# Altium schematic sheet power.SchDoc
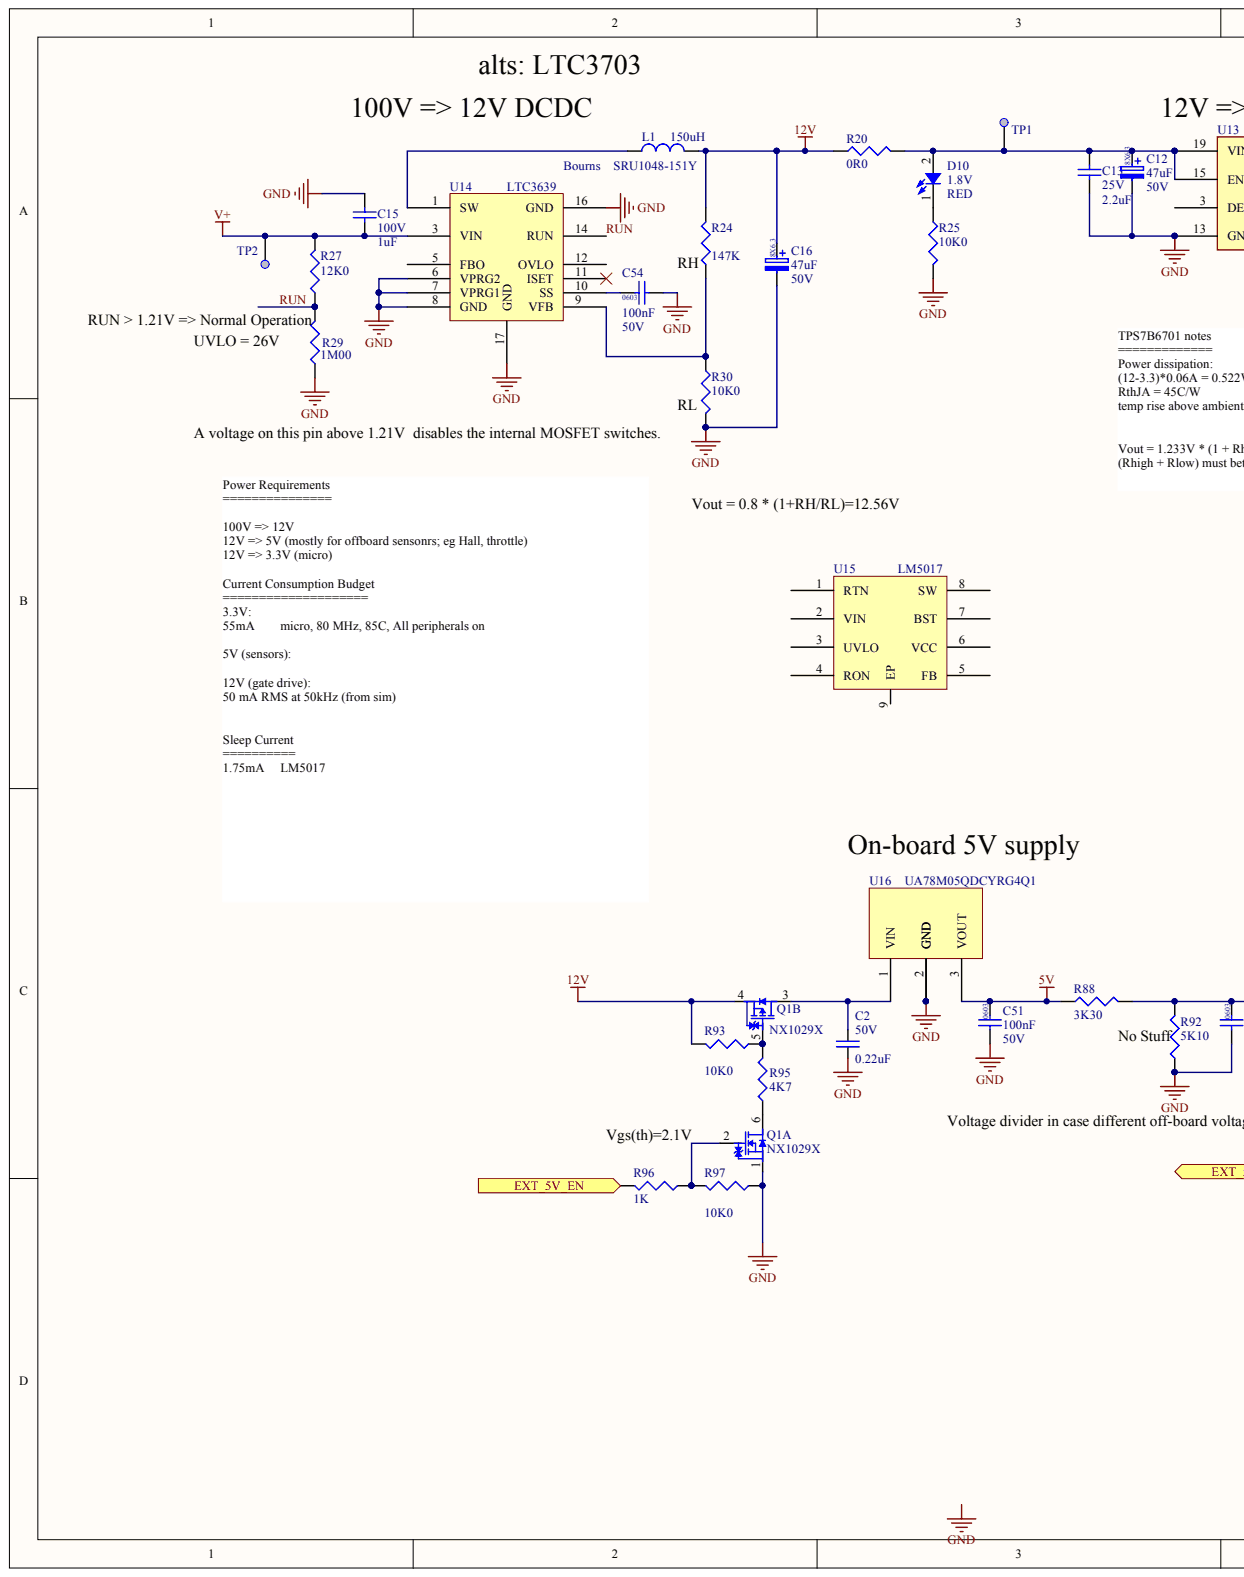
[diagram p0: power.SchDoc, left]
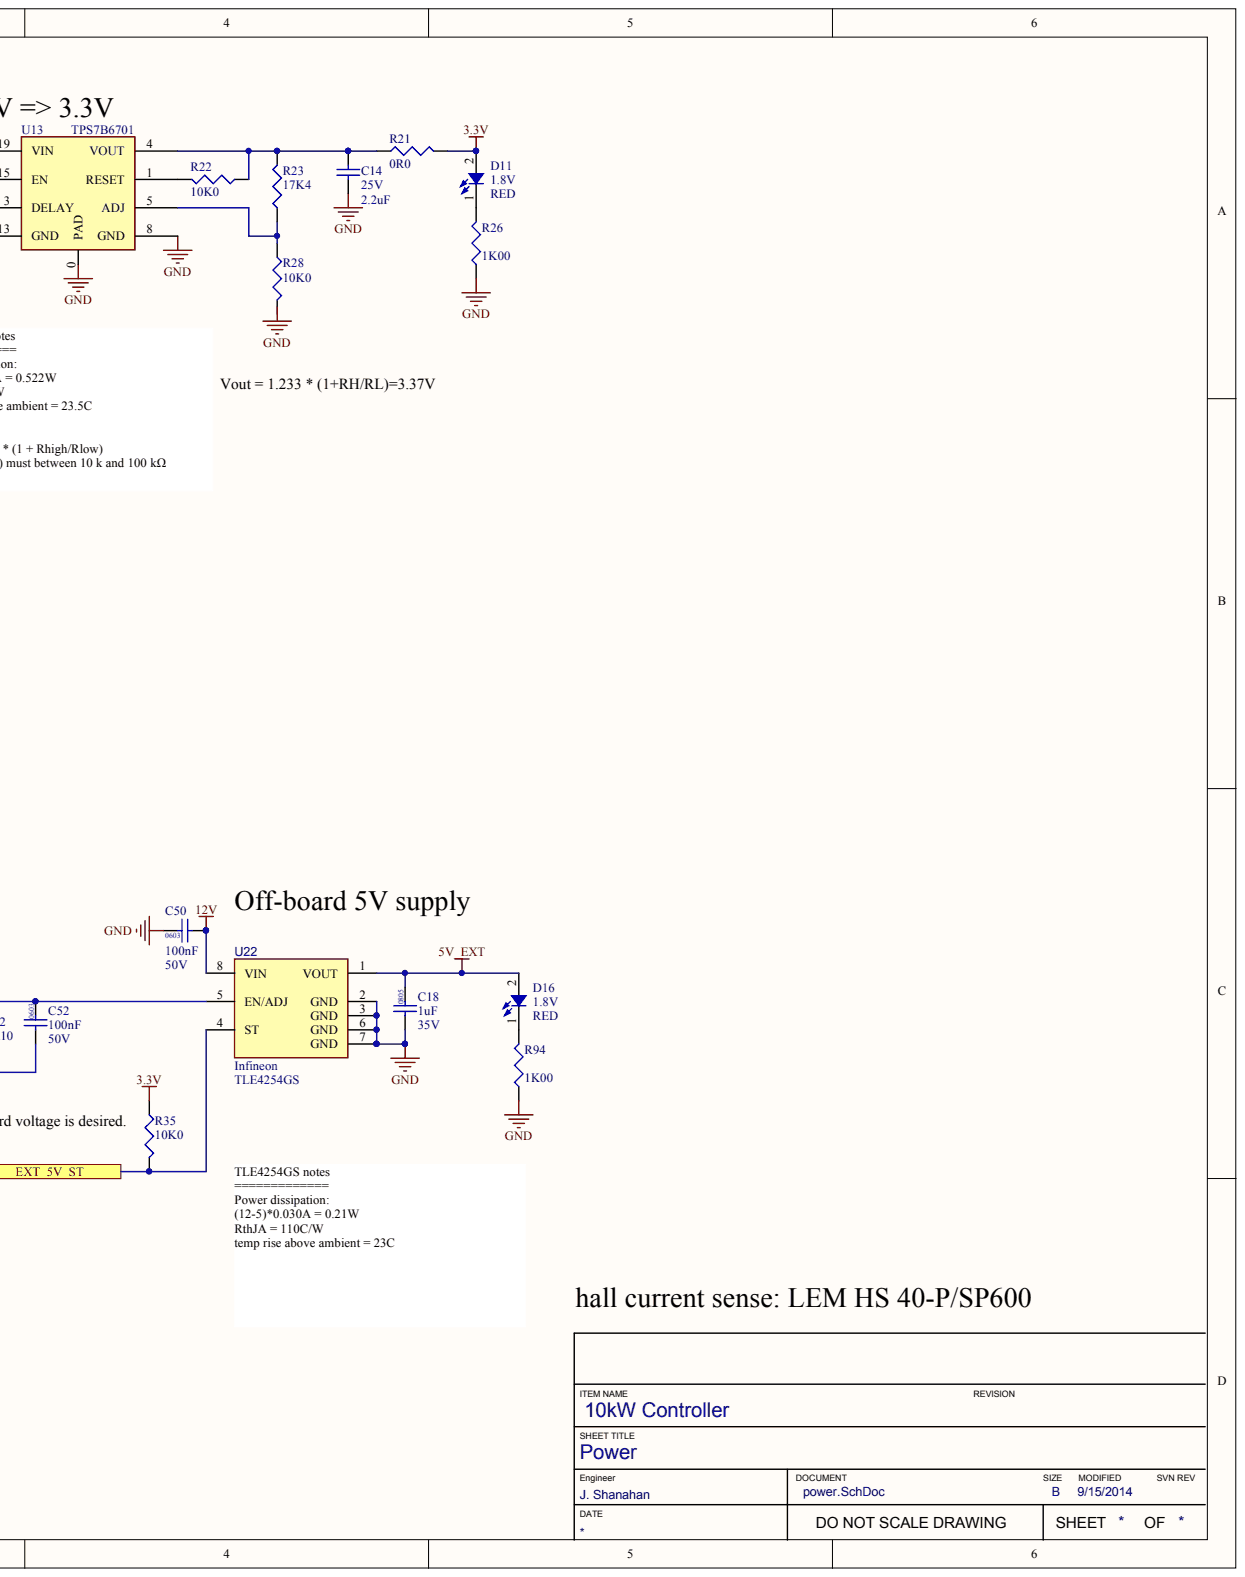
[diagram p1: power.SchDoc, right]

## Parts
C2  value 0.22uF  voltage: 50V  (hidden comment: 0.22UF,25V,X7R(0603))
C12  47uF  voltage: 50V
C13  value 2.2uF  voltage: 25V  (hidden comment: 2.2UF,25V,X7R(0805))
C14  value 2.2uF  voltage: 25V  (hidden comment: 2.2UF,25V,X7R(0805))
C15  value 1uF  voltage: 100V  (hidden comment: 1UF,100V,X7R(1206))
C16  47uF  voltage: 50V
C18  Voltage: 35V  (hidden comment: 1uF)
C50  value 100nF  voltage: 50V  (hidden comment: 2005864)
C51  value 100nF  voltage: 50V  (hidden comment: 2005864)
C52  value 100nF  voltage: 50V  (hidden comment: 2005864)
C54  voltage: 50V  (hidden comment: 100nF)
D10  Voltage: 1.8V  Color: RED
D11  Voltage: 1.8V  Color: RED
D16  Voltage: 1.8V  Color: RED
L1  value 150uH  MFG1_PN: SRU1048-151Y  (hidden comment: 150uH,0.800A)
Q1  NX1029X  (parts A,B)
R20  value 0R0  (hidden comment: 0R0,0.10W,JMP(0603))
R21  value 0R0  (hidden comment: 0R0,0.10W,JMP(0603))
R22  10K0
R23  value 17K4  (hidden comment: 17K4,0.10W,1%(0603))
R24  147K
R25  10K0
R26  1K00
R27  value 12K0  (hidden comment: RC0603FR-0712KL)
R28  10K0
R29  value 1M00
R30  10K0
R35  value 10K0  (hidden comment: 2006240)
R88  value 3K30  (hidden comment: 3K30,0.12W,1%(0805))
R92  value 5K10  (hidden comment: CRCW06035K10FKEA)
R93  10K0
R94  1K00
R95  4K7
R96  1K
R97  10K0
TP1  TEST-POINT1  (value not drawn)
TP2  TEST-POINT1  (value not drawn)
U13  TPS7B6701
U14  LTC3639
U15  LM5017
U16  UA78M05QDCYRG4Q1
U22  TLE4254GS  MFG1: Infineon

## Ports
EXT_5V_EN, EXT_5V_ST

## Nets
3.3V: D11.2 R21.2 R35.1
5V: C51.1 R88.1 U16.3(VOUT)
5V_EXT: C18.1 D16.2 U22.1(VOUT)
12V: C16.1 C50.2 L1.2 Q1.4 R20.1 R24.2 R93.1 U22.8(VIN)
GND: C2.1 C12.2 C13.1 C14.1 C15.1 C16.2 C18.2 C50.1 C51.2 C52.2 C54.2 Q1.1 R25.2 R26.1 R29.1 R30.2 R92.1 R94.1 R97.2 U13.0(PAD) U13.8(GND) U13.13(GND) U14.6(VPRG2) U14.7(VPRG1) U14.8(GND) U14.16(GND) U14.17(GND) U16.2(GND) U16.4 U22.2(GND) U22.3(GND) U22.6(GND) U22.7(GND)
V+: C15.2 R27.2 TP2.1 U14.3(VIN)
EXT_5V_EN: R96.1 ; port EXT_5V_EN
EXT_5V_ST: R35.2 U22.4(ST) ; port EXT_5V_ST
RUN: R27.1 R29.2 U14.14(RUN)
NetC2_2: C2.2 Q1.3 U16.1(VIN)
NetC12_1: C12.1 C13.2 D10.2 R20.2 TP1.1 U13.15(EN) U13.19(VIN)
NetC14_2: C14.2 R21.1 R22.1 R23.2 U13.4(VOUT)
NetC52_1: C52.1 R88.2 R92.2 U22.5(EN/ADJ)
NetC54_1: C54.1 U14.10(SS)
NetD10_1: D10.1 R25.1
NetD11_1: D11.1 R26.2
NetD16_1: D16.1 R94.2
NetL1_1: L1.1 U14.1(SW)
NetQ1_2: Q1.2 R96.2 R97.1
NetQ1_5: Q1.5 R93.2 R95.2
NetQ1_6: Q1.6 R95.1
NetR22_2: R22.2 U13.1(RESET)
NetR23_1: R23.1 R28.1 U13.5(ADJ)
NetR24_1: R24.1 R30.1 U14.9(VFB)

Not connected: R28 2; U13 3(DELAY); U14 5(FBO) 11(ISET) 12(OVLO); U15 1(RTN) 2(VIN) 3(UVLO) 4(RON) 5(FB) 6(VCC) 7(BST) 8(SW) 9(EP)

## Library data of the parts
C2 = (no symbol name): MFG1_PN: GCM188R71E224KA55D; MFG1: Murata
C12 C16 = (no symbol name): tolerance: 20%; LEVEL: 1; MFG1_PN: UCD1H470MCL6GS; MFG1: Nichicon; Load: NL; SAP: 1019592-00-A; Automotive: AEC-Q; RoHS: YES; Value: 47uF
C13 C14 = (no symbol name): tolerance: 10%; TPN: 01-006909-00; LEVEL: 1; MFG1: MURATA; MFG1_PN: GCM21BR71E225KA73L; SAP: 2005869; Revision: July-2002: Re-released for DXP Platform.; TESLA_LIB: 13-JUL-06; RoHS: YES; Automotive: AEC-Q; Supplier 1: Digi-Key; Supplier 2: Digi-Key; Supplier Part Number 1: 490-4787-6-ND; Supplier Part Number 2: 490-4787-2-ND
C15 = (no symbol name): MFG1: TDK; MFG1_PN: CGA5L2X7R2A105K160AE; Revision: July-2002: Re-released for DXP Platform.; Unit Price: 1.02
C18 = (no symbol name): Tolerance: 10%; MFG1_PN: GCM21BR7YA105KA55L; MFG1: Murata; Load: NL; Detail Description: 1uF Capacitor, 35V, X7R, 10%, in 0805 package, Automotive Grade.; Enovia: 1009854-00-A; TPN: N/A; Value: 1uF; SAP: 1009854-00-A
C50-C52 = (no symbol name): tolerance: 10%; MFG1_PN: GCM188R71H104KA57D; MFG1: Murata; Load: NL; Detail Description: 100nF Capacitor, 50V, X7R, 10%, in 0603 package, Automotive Grade.; TPN: 01-006841-00; Enovia: 2005864; SAP: 2005864
C54 = (no symbol name): tolerance: 10%; MFG1_PN: GCM188R71H104KA57D; MFG1: Murata; Load: NL; Detail Description: 100nF Capacitor, 50V, X7R, 10%, in 0603 package, Automotive Grade.; TPN: 01-006841-00; Enovia: 2005864; Value: 100nF; SAP: 2005864
D10 D11 D16 = (no symbol name): LEVEL: 1; MFG1: Osram; MFG1_PN: LS L29K-H1J2-1-Z; Typ Current: 5mA; SAP: 2008044; RoHS: YES
L1 = (no symbol name): MFG1: Bourns; Revision: July-2002: Re-released for DXP Platform.; Unit Price: 0.80
Q1 = (no symbol name): MFG1: NXP; Load: NL; Detail Description: Mosfet, Dual N and P Channel, 60/-50V, .67A, in SOT-563 package, RoHS, automotive grade.; Current: 330/-170mA; SAP: 1030180-00-A; MFG1_PN: NX1029X; TPN: N/A
R20 R21 = (no symbol name): Tolerance: 1%; LEVEL: 1; MFG1_PN: CRCW06030000Z0EA; MFG1: VISHAY; Load: NL; TPN: 01-009258-00; SAP: 2008023; Revision: July-2002: Re-released for DXP Platform.; RoHS: YES; POWER: 0.10W; Automotive: YES
R22 R25 R28 R30 = (no symbol name): Tolerance: 1%; POWER: 0.10W; MFG1_PN: CRCW060310K0FKEA; MFG1: Vishay; Load: NL; Detail Description: Resistor, 10K0, 0603 package, automotive grade.; TPN: 01-007331-00; Enovia: 2006240; Revision: July-2002: Re-released for DXP Platform.; RoHS: Yes; SAP: 2006240; Value: 10K0
R23 = (no symbol name): TPN: 01-007424-00; LEVEL: 1; MFG1_PN: MCR03EZPFX1742; MFG1: ROHM; SAP: 2000904; Revision: July-2002: Re-released for DXP Platform.; Tolerance: 1%; RoHS: YES; POWER: 0.10W; Automotive: YES; Supplier 1: Digi-Key; Supplier 2: Digi-Key; Supplier Part Number 1: RHM17.4KHDKR-ND; Supplier Part Number 2: RHM17.4KHTR-ND
R24 = (no symbol name): Tolerance: 1%; POWER: 0.10W; MFG1_PN: CRCW0603147KFKEA; MFG1: Vishay; Load: NL; Detail Description: Resistor, 147K, 0603 package, automotive grade.; TPN: 01-008738-00; Revision: July-2002: Re-released for DXP Platform.; RoHS: YES; Value: 147K
R26 R94 = (no symbol name): Tolerance: 1%; POWER: 0.10W; MFG1_PN: CRCW06031K00FKEA; MFG1: Vishay; Load: NL; Detail Description: Resistor, 1K, 0603 package, automotive grade.; TPN: 01-007330-00; Enovia: 2006259; Revision: July-2002: Re-released for DXP Platform.; RoHS: YES; Value: 1K00
R27 = (no symbol name): Tolerance: 1%; TPN: 01-002220-00; POWER: 0.10W; LEVEL: 1; MFG1: YAGEO; SAP: 2005435; Revision: July-2002: Re-released for DXP Platform.; RoHS: YES; MFG1_PN: RC0603FR-0712KL; Supplier 1: Digi-Key; Supplier 2: Digi-Key; Supplier Part Number 1: 311-12.0KHRTR-ND; Supplier Part Number 2: 311-12.0KHRDKR-ND
R29 = (no symbol name): Tolerance: 1%; TPN: 01-007340-00; POWER: 0.10W; LEVEL: 1; MFG1_PN: CRCW06031M00FKEA; MFG1: VISHAY/DALE; Revision: July-2002: Re-released for DXP Platform.; RoHS: YES; Automotive: YES; Supplier 1: Digi-Key; Supplier 2: Digi-Key; Supplier Part Number 1: 541-1.00MHDKR-ND; Supplier Part Number 2: 541-1.00MHTR-ND; Comment: =SAP
R35 = (no symbol name): Tolerance: 1%; POWER: 0.10W; MFG1_PN: CRCW060310K0FKEA; MFG1: Vishay; Load: NL; Detail Description: Resistor, 10K0, 0603 package, automotive grade.; TPN: 01-007331-00; Revision: July-2002: Re-released for DXP Platform.; RoHS: Yes; Enovia: 2006240; SAP: 2006240
R88 = (no symbol name): POWER: 0.125W; LEVEL: 1; MFG1_PN: CRCW08053K30FKEA; MFG1: VISHAY; SAP: 1003812-00-A; Revision: July-2002: Re-released for DXP Platform.; Tolerance: 1%; RoHS: YES; Automotive: YES
R92 = (no symbol name): Tolerance: 1%; POWER: 0.125W; LEVEL: 1; MFG1: VISHAY; SAP: 1004723-00-A; Revision: July-2002: Re-released for DXP Platform.; RoHS: YES; Automotive: YES; MFG1_PN: CRCW06035K10FKEA
R93 R97 = (no symbol name): Tolerance: 1%; POWER: 0.0625W; MFG1_PN: CRCW040210K0FKED; MFG1: Vishay; Load: NL; Detail Description: Resistor, 10K0, 0402 package, automotive grade.; TPN: 01-008643-00; SAP: 2007941; Revision: July-2002: Re-released for DXP Platform.; RoHS: YES; Value: 10K0; Enovia: 2007941
R95 = (no symbol name): Tolerance: 1%; POWER: 0.10W; MFG1_PN: CRCW06034K70FKEA; MFG1: Vishay; Load: NL; Detail Description: Resistor, 4K7, 0603 package, automotive grade.; SAP: 1006590-00-A; Revision: July-2002: Re-released for DXP Platform.; RoHS: YES; Value: 4K7; Enovia: 1006590-00-A
R96 = (no symbol name): Tolerance: 1%; POWER: 0.0625W; MFG1_PN: CRCW04021K00FKED; MFG1: Vishay; Load: NL; Detail Description: Resistor, 1K, 0402 package, automotive grade.; TPN: 01-009020-00; Enovia: 2008037; Revision: July-2002: Re-released for DXP Platform.; RoHS: YES; Value: 1K; SAP: 2008037
U13 = (no symbol name): MFG1_PN: TPS7B6701QPWPRQ1; MFG1: Texas Instruments
U14 = (no symbol name): MFGPN: LTC3639EMSE#PBF; MFG: LTC
U15 = (no symbol name): MFGPN: LM5017MR/NOPB; MFG: TI; Unit Price: 4.74
U16 = (no symbol name): MFG1: TI; MFG1_PN: UA78M05QDCYRG4Q1; Price: .76
TP1 TP2 U22 = (no symbol name)
  U22: Unit Price: 1.78; MFG1_PN: TLE4254GS
